# Altium schematic sheet Power_Analog.SchDoc("Power Analog")
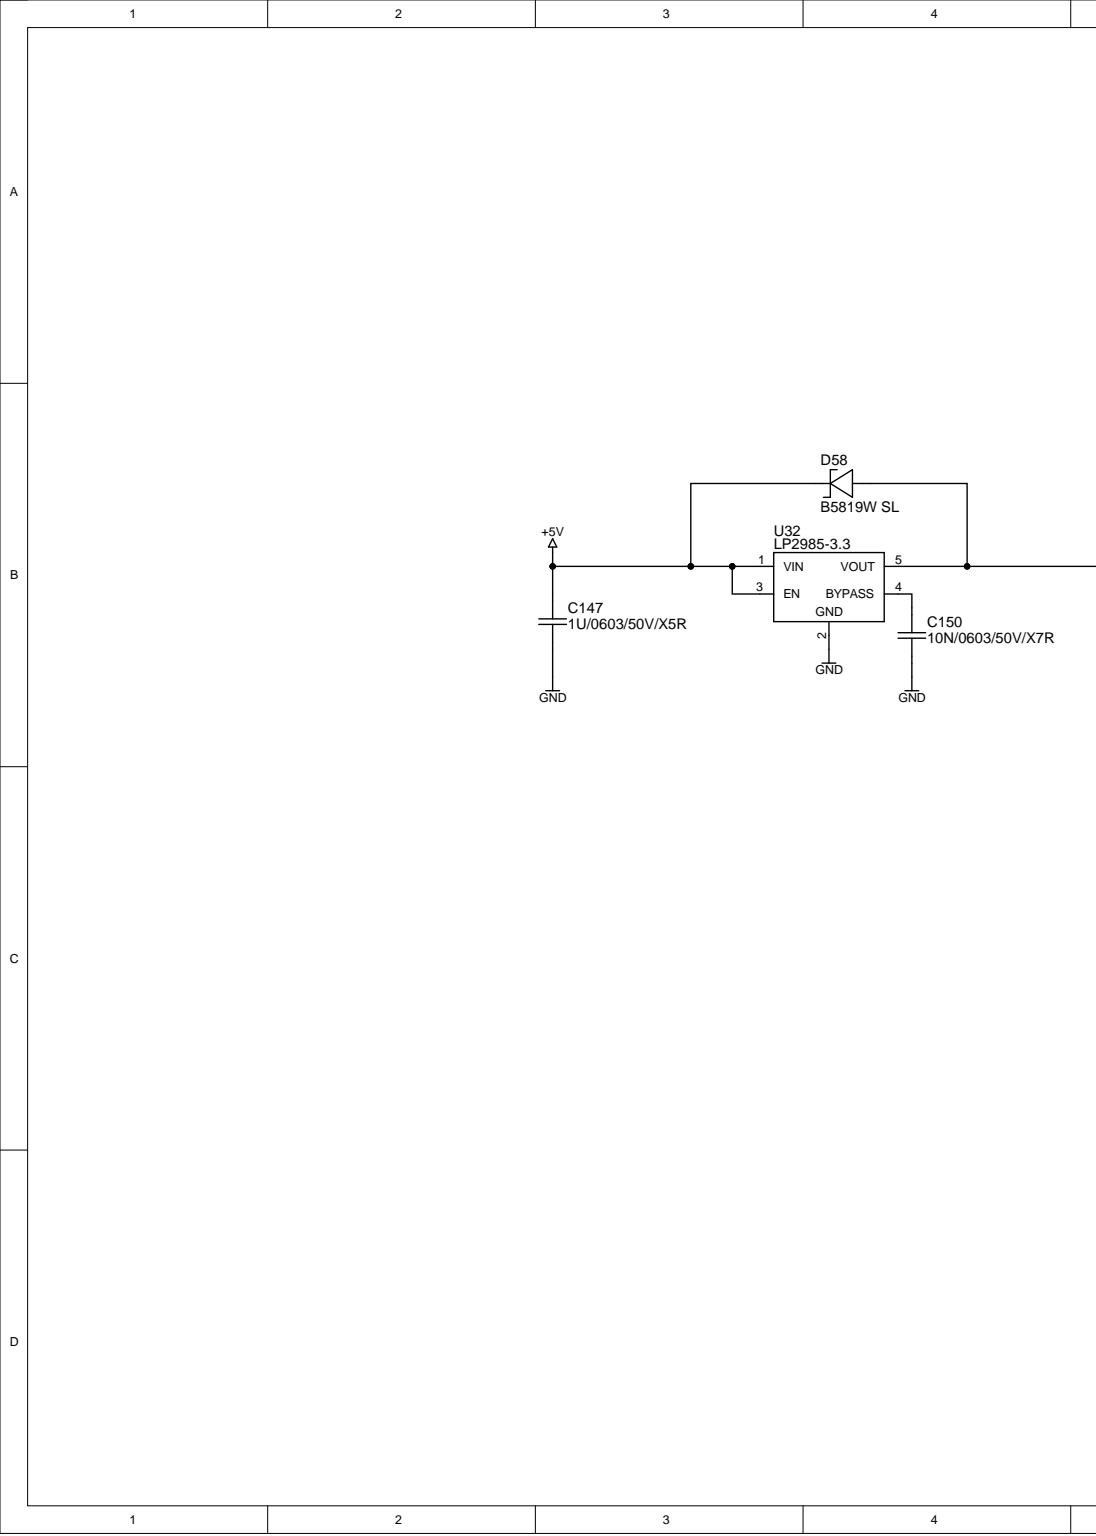
[diagram p0: Power_Analog.SchDoc, left]
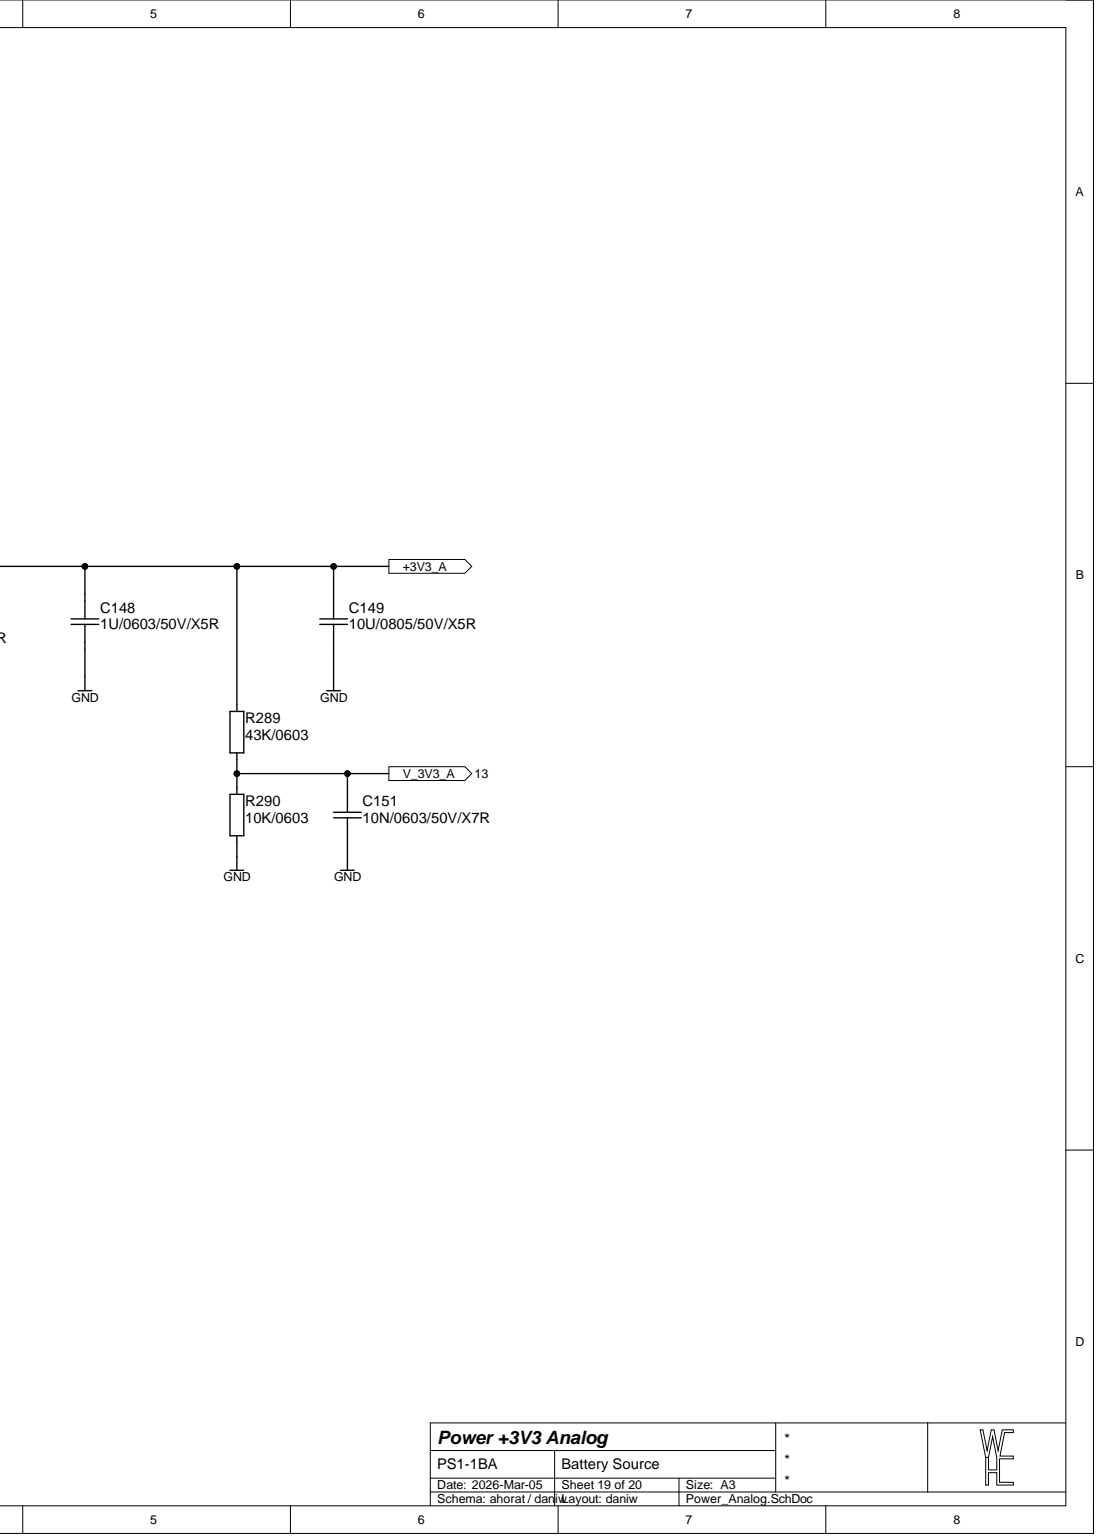
[diagram p1: Power_Analog.SchDoc, right]

## Parts
C147  1U/0603/50V/X5R  [CAP0603_X7R]
C148  1U/0603/50V/X5R  [CAP0603_X7R]
C149  10U/0805/50V/X5R  [CAP0805_X7R]
C150  10N/0603/50V/X7R  [CAP0603_X7R]
C151  10N/0603/50V/X7R  [CAP0603_X7R]
D58  B5819W SL  [SOD3718X125]
R289  43K/0603  [R0603-0.40-WW]
R290  10K/0603  [R0603-0.40-WW]
U32  LP2985-3.3  [SOT2395P280X125-5-SW]

## Ports
+3V3_A, V_3V3_A

## Nets
+5V: C147.1 D58.1 U32.1(VIN) U32.3(EN)
GND: C147.2 C148.2 C149.2 C150.2 C151.2 R290.2 U32.2
+3V3_A: C148.1 C149.1 D58.2 R289.1 U32.5(VOUT) ; port +3V3_A
V_3V3_A: C151.1 R289.2 R290.1 ; port V_3V3_A
NetC150_1: C150.1 U32.4(BYPASS)

## Library data of the parts
C147 C148 = 1U/0603/50V/X5R: CAP SM 0603 1.0uF 50V X5R; Manufacturer: GENERIC; Manufacturer 1: Samsung Electro-Mechanics; Manufacturer Part Number 1: CL10A105KB8NNNC; Absolute Value: 1E-6; Database Table Name: C$; Eurocircuits GPN: GPC0603105-X5R; Component Kind: Standard; HelpURL: https://mm.digikey.com/Volume0/opasdata/d220001/medias/docus/658/CL10A105KB8NNNC_Spec.pdf; JLC Type: Basic; Manufacturer Part Number 3: CL10A105KB8NNNC; Package: 0603; Part Number: 1U/0603/50V/X5R; Supplier 1: Digi-Key; Supplier 3: LCSC; Supplier Part Number 1: 1276-1860-1-ND; Supplier Part Number 3: C15849; Value: 1.0uF; Library Name: daniw_altium_lib.DbLib
C149 = 10U/0805/50V/X5R: CAP SM 0805 10uF 50V X5R; Manufacturer: GENERIC; Manufacturer 1: Murata Electronics; Manufacturer Part Number 1: GRM21BR61H106KE43K; Absolute Value: 1E-5; Database Table Name: C$; Eurocircuits GPN: GPN0805106-50-X5R; Component Kind: Standard; HelpURL: https://search.murata.co.jp/Ceramy/image/img/A01X/G101/ENG/GRM21BR61H106KE43-01A.pdf; JLC Type: Basic; Manufacturer Part Number 3: GRM21BR61H106KE43L; Package: 0805; Part Number: 10U/0805/50V/X5R; Supplier 1: Digi-Key; Supplier 3: LCSC; Supplier Part Number 1: 490-GRM21BR61H106KE43KCT-ND; Supplier Part Number 3: C440198; Value: 10uF; Library Name: daniw_altium_lib.DbLib
C150 C151 = 10N/0603/50V/X7R: CAP SM 0603 10nF 50V X7R; Manufacturer: GENERIC; Manufacturer 1: YAGEO; Manufacturer Part Number 1: CC0603KPX7R9BB103; Absolute Value: 1E-8; Database Table Name: C$; Eurocircuits GPN: GPC0603103; Component Kind: Standard; HelpURL: https://www.yageo.com/upload/media/product/app/datasheet/mlcc/upy-gphc_x7r_6_3v-to-250v.pdf; Manufacturer 2: YAGEO; Manufacturer Part Number 2: CC0603KRX7R9BB103; Manufacturer Part Number 3: CC0603KRX7R9BB103; Package: 0603; Part Number: 10N/0603/50V/X7R; Supplier 1: Digi-Key; Supplier 2: Digi-Key; Supplier 3: LCSC; Supplier Part Number 1: 311-1572-1-ND; Supplier Part Number 2: 311-1085-1-ND; Supplier Part Number 3: C100042; Value: 10nF; Library Name: daniw_altium_lib.DbLib
D58 = B5819W SL: 40V 600mV@1A 1A SOD-123 Schottky Barrier Diodes; Manufacturer: GENERIC; Manufacturer 1: SMC Diode Solutions; Database Table Name: D$; Absolute Value: 40V; Component Kind: Standard; HelpURL: https://datasheet.lcsc.com/lcsc/2304140030_Jiangsu-Changjing-Electronics-Technology-Co---Ltd--B5819W-SL_C8598.pdf; JLC Type: Basic; Manufacturer 3: Jiangsu Changjing Electronics Technology Co., Ltd.; Manufacturer Part Number 1: B5819WSL; Manufacturer Part Number 3: B5819W SL; Package: SOD-123; Part Number: B5819W SL; Supplier 1: Digikey; Supplier 3: LCSC; Supplier Part Number 1: 1655-B5819WSLCT-ND; Supplier Part Number 3: C8598; Value: B5819W SL; Library Name: daniw_altium_lib.DbLib
R289 = 43K/0603: RES SM 0603 43K; Manufacturer: GENERIC; Manufacturer 1: YAGEO; Manufacturer Part Number 1: RC0603FR-1343KL; Absolute Value: 43000; Database Table Name: R$; Eurocircuits GPN: GPR060343K; Component Kind: Standard; HelpURL: https://www.yageogroup.com/content/datasheet/asset/file/PYU-RC_GROUP_51_ROHS_L; JLC Type: Extended; Manufacturer 2: YAGEO; Manufacturer 3: YAGEO; Manufacturer Part Number 2: RC0603FR-1043KL; Manufacturer Part Number 3: RC0603FR-0743KL; Package: 0603; Part Number: 43K/0603; Supplier 1: Digi-Key; Supplier 2: Digi-Key; Supplier 3: LCSC; Supplier Part Number 1: 13-RC0603FR-1343KLCT-ND; Supplier Part Number 2: 13-RC0603FR-1043KLCT-ND; Supplier Part Number 3: C137719; Value: 43K; Library Name: daniw_altium_lib.DbLib
R290 = 10K/0603: RES SM 0603 10K; Manufacturer: GENERIC; Manufacturer 1: YAGEO; Manufacturer Part Number 1: RC0603FR-1310KL; Absolute Value: 10000; Database Table Name: R$; Eurocircuits GPN: GPR060310K; Component Kind: Standard; HelpURL: https://www.yageogroup.com/content/datasheet/asset/file/PYU-RC_GROUP_51_ROHS_L; JLC Type: Basic; Manufacturer 2: YAGEO; Manufacturer 3: UNI-ROYAL(Uniroyal Elec); Manufacturer Part Number 2: RC0603FR-1010KL; Manufacturer Part Number 3: 0603WAF1002T5E; Package: 0603; Part Number: 10K/0603; Supplier 1: Digi-Key; Supplier 2: Digi-Key; Supplier 3: LCSC; Supplier Part Number 1: 13-RC0603FR-1310KLCT-ND; Supplier Part Number 2: 13-RC0603FR-1010KLCT-ND; Supplier Part Number 3: C25804; Value: 10K; Library Name: daniw_altium_lib.DbLib
U32 = LP2985-3.3: LP2985 150mA, Low-Noise, Low-Dropout Regulator With Shutdown, 1.8V; Manufacturer: Texas Instruments; Manufacturer Part Number 1: LP2985-33DBVR; Database Table Name: Integrated$; Component Kind: Standard; HelpURL: https://www.ti.com/lit/ds/symlink/lp2985.pdf; JLC Type: Extended; Manufacturer 1: Texas Instruments; Manufacturer 2: Texas Instruments; Manufacturer 3: TI; Manufacturer Part Number 2: LP2985-33DBVRM3; Manufacturer Part Number 3: LP2985-33DBVR; Package: SOT23-5; Part Number: LP2985-3.3; Supplier 1: Digi-Key; Supplier 2: Digi-Key; Supplier 3: LCSC; Supplier Part Number 1: 296-18476-1-ND; Supplier Part Number 2: 296-LP2985-33DBVRM3CT-ND; Supplier Part Number 3: C95414; Library Name: daniw_altium_lib.DbLib
